# Altium schematic sheet Rectifiers.SchDoc(Rectifiers)
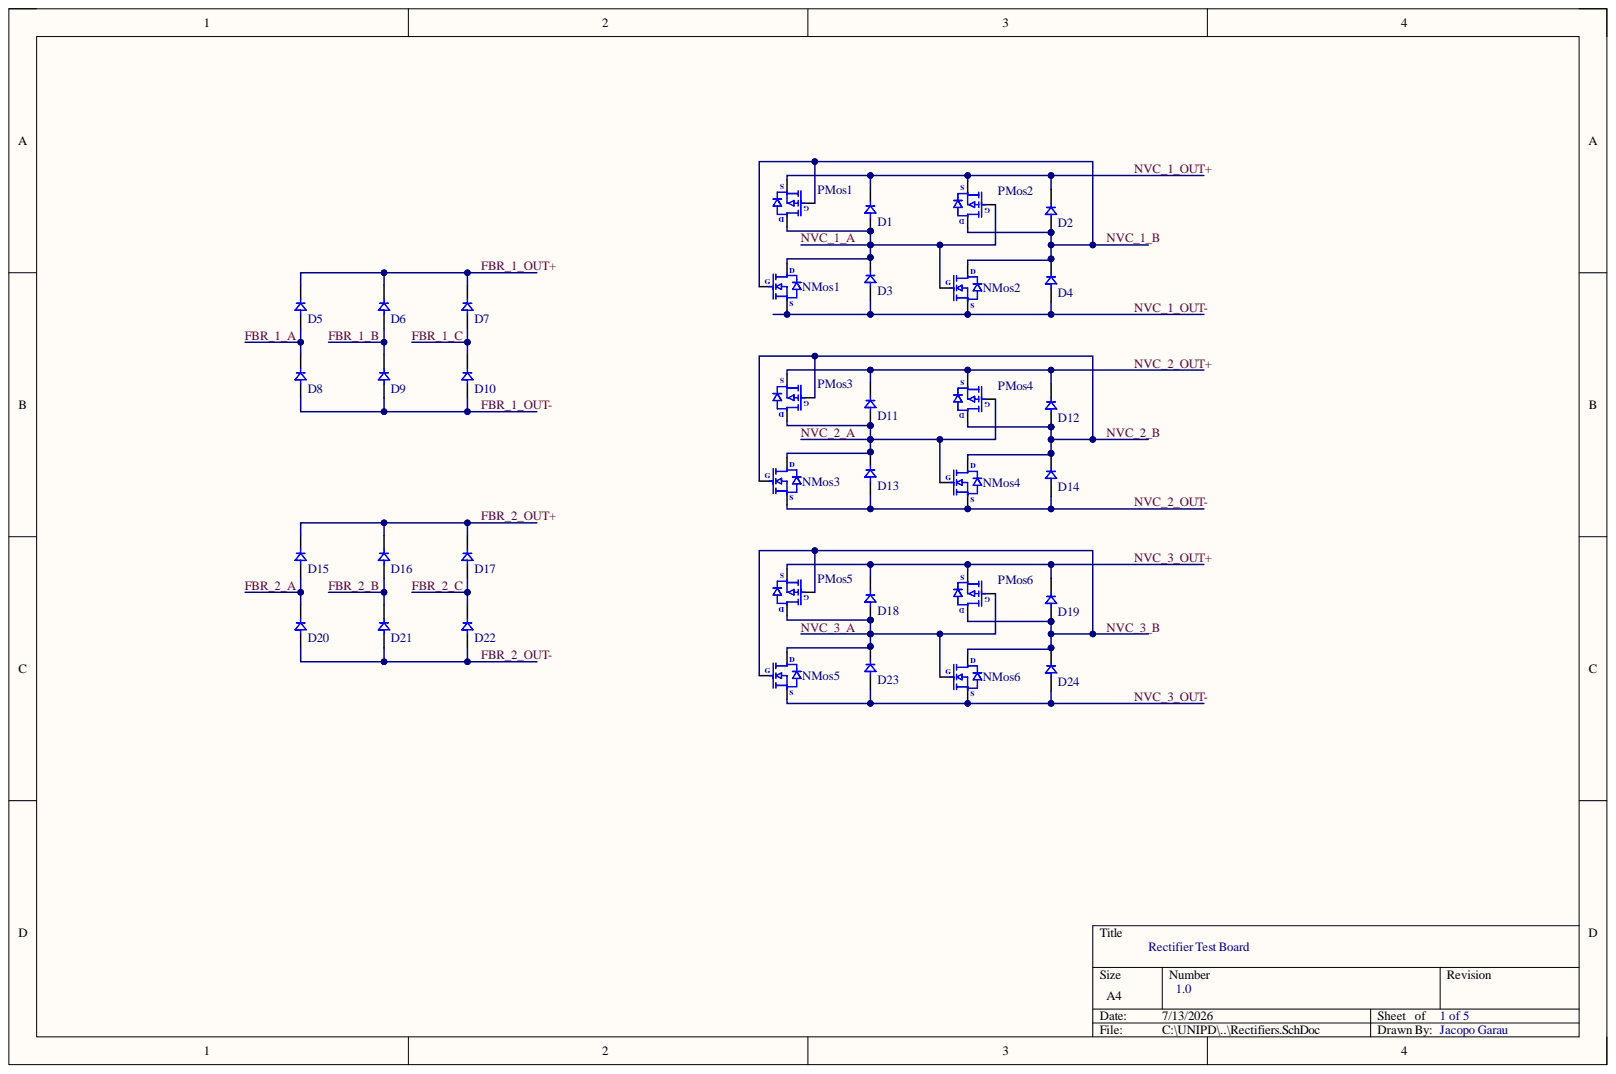
[diagram p0: Rectifiers.SchDoc]

## Parts
D1  CTS05S40,L3F  [CST2_TOS]  (value not drawn)
D2  CTS05S40,L3F  [CST2_TOS]  (value not drawn)
D3  CTS05S40,L3F  [CST2_TOS]  (value not drawn)
D4  CTS05S40,L3F  [CST2_TOS]  (value not drawn)
D5  CTS05S40,L3F  [CST2_TOS]  (value not drawn)
D6  CTS05S40,L3F  [CST2_TOS]  (value not drawn)
D7  CTS05S40,L3F  [CST2_TOS]  (value not drawn)
D8  CTS05S40,L3F  [CST2_TOS]  (value not drawn)
D9  CTS05S40,L3F  [CST2_TOS]  (value not drawn)
D10  CTS05S40,L3F  [CST2_TOS]  (value not drawn)
D11  CTS05S40,L3F  [CST2_TOS]  (value not drawn)
D12  CTS05S40,L3F  [CST2_TOS]  (value not drawn)
D13  CTS05S40,L3F  [CST2_TOS]  (value not drawn)
D14  CTS05S40,L3F  [CST2_TOS]  (value not drawn)
D15  CTS05S40,L3F  [CST2_TOS]  (value not drawn)
D16  CTS05S40,L3F  [CST2_TOS]  (value not drawn)
D17  CTS05S40,L3F  [CST2_TOS]  (value not drawn)
D18  CTS05S40,L3F  [CST2_TOS]  (value not drawn)
D19  CTS05S40,L3F  [CST2_TOS]  (value not drawn)
D20  CTS05S40,L3F  [CST2_TOS]  (value not drawn)
D21  CTS05S40,L3F  [CST2_TOS]  (value not drawn)
D22  CTS05S40,L3F  [CST2_TOS]  (value not drawn)
D23  CTS05S40,L3F  [CST2_TOS]  (value not drawn)
D24  CTS05S40,L3F  [CST2_TOS]  (value not drawn)
NMos1  BSS138PW,115  [SC-70_SOT323_NEX-L]  (value not drawn)
NMos2  BSS138PW,115  [SC-70_SOT323_NEX-L]  (value not drawn)
NMos3  BSS138PW,115  [SC-70_SOT323_NEX-L]  (value not drawn)
NMos4  BSS138PW,115  [SC-70_SOT323_NEX-L]  (value not drawn)
NMos5  BSS138PW,115  [SC-70_SOT323_NEX-L]  (value not drawn)
NMos6  BSS138PW,115  [SC-70_SOT323_NEX-L]  (value not drawn)
PMos1  PMN27XPE,115  [PMN27XPE,115]  (value not drawn)
PMos2  PMN27XPE,115  [PMN27XPE,115]  (value not drawn)
PMos3  PMN27XPE,115  [PMN27XPE,115]  (value not drawn)
PMos4  PMN27XPE,115  [PMN27XPE,115]  (value not drawn)
PMos5  PMN27XPE,115  [PMN27XPE,115]  (value not drawn)
PMos6  PMN27XPE,115  [PMN27XPE,115]  (value not drawn)

## Nets
FBR_1_A: D5.2 D8.1
FBR_1_B: D6.2 D9.1
FBR_1_C: D7.2 D10.1
FBR_1_OUT+: D5.1 D6.1 D7.1
FBR_1_OUT-: D8.2 D9.2 D10.2
FBR_2_A: D15.2 D20.1
FBR_2_B: D16.2 D21.1
FBR_2_C: D17.2 D22.1
FBR_2_OUT+: D15.1 D16.1 D17.1
FBR_2_OUT-: D20.2 D21.2 D22.2
NVC_1_A: D1.2 D3.1 NMos1.3 NMos2.1 PMos1.1 PMos2.3
NVC_1_B: D2.2 D4.1 NMos1.1 NMos2.3 PMos1.3 PMos2.1
NVC_1_OUT+: D1.1 D2.1 PMos1.4 PMos2.4
NVC_1_OUT-: D3.2 D4.2 NMos1.2 NMos2.2
NVC_2_A: D11.2 D13.1 NMos3.3 NMos4.1 PMos3.1 PMos4.3
NVC_2_B: D12.2 D14.1 NMos3.1 NMos4.3 PMos3.3 PMos4.1
NVC_2_OUT+: D11.1 D12.1 PMos3.4 PMos4.4
NVC_2_OUT-: D13.2 D14.2 NMos3.2 NMos4.2
NVC_3_A: D18.2 D23.1 NMos5.3 NMos6.1 PMos5.1 PMos6.3
NVC_3_B: D19.2 D24.1 NMos5.1 NMos6.3 PMos5.3 PMos6.1
NVC_3_OUT+: D18.1 D19.1 PMos5.4 PMos6.4
NVC_3_OUT-: D23.2 D24.2 NMos5.2 NMos6.2

## Library data of the parts
D1-D24 = CTS05S40,L3F: Rectifier Diode, Schottky, 1 Phase, 1 Element, 0.5A, 40V V(RRM), Silicon; Manufacturer: Toshiba; Average Rectified Current: 500mA; Element Configuration: Single; Forward Voltage: 600mV; Max Forward Surge Current (Ifsm): 2A; Number of Elements: 1; Peak Reverse Current: 50µA; Case/Package: SOD; Category: Rectifier Diodes; Component Kind: Standard; ComponentLink1Description: https://datasheet.octopart.com:443/CTS05S40%2CL3F-Toshiba-datasheet-181389556.pdf?src-supplier=IHS; ComponentLink1URL: https://datasheet.octopart.com:443/CTS05S40%2CL3F-Toshiba-datasheet-181389556.pdf?src-supplier=IHS; LCSC Part Number: C396063; Library Name: MySchLib.SchLib; Lifecycle Status: Production; Manufacturer Part Number: CTS05S40,L3F; Max Operating Temperature: 125°C; Max Output current: 500mA; Max Reverse Voltage (DC): 40V; Min Operating Temperature: -55°C; Mounting Technology: Surface Mount; Number of Terminals: 2; Packaging: Tape & Reel (TR); Peak Non-Repetitive Surge Current: 2A; Pins: 2; Pricing: 1=0.13, 10=0.098, 100=0.0744, 500=0.0642, 1000=0.05835, 2000=0.0504 (USD); REACH SVHC: Yes; Reverse Standoff Voltage: 40V; RoHS: Compliant; Schedule B: 8541100070, 8541100070|8541100070, 8541100070|8541100070|8541100070, 8541100070|8541100070|8541100070|8541100070; Stock: 18197; Supplier: DigiKey; Supplier Part Number: CTS05S40L3FCT-ND
NMos1-NMos6 = BSS138PW,115: Transistor MOSFET N-CH 60V 0.32A Automotive 3-Pin SC-70 T/R; Manufacturer: Nexperia; Manufacturer Lifecycle Status: RELEASED FOR SUPPLY; Continuous Drain Current (ID): 320mA; Drain to Source Resistance: 1.6Ω; Max Power Dissipation: 310mW; Number of Elements: 1; Category: MOSFETs; China RoHS: Compliant; Component Kind: Standard; ComponentLink1Description: https://datasheet.octopart.com:443/BSS138PW%2C115-Nexperia-datasheet-87581096.pdf?src-supplier=Newark; ComponentLink1URL: https://datasheet.octopart.com:443/BSS138PW%2C115-Nexperia-datasheet-87581096.pdf?src-supplier=Newark; ComponentLink2Description: https://datasheet.octopart.com:443/BSS138PW%2C115-Nexperia-datasheet-10542180.pdf?src-supplier=IHS; ComponentLink2URL: https://datasheet.octopart.com:443/BSS138PW%2C115-Nexperia-datasheet-10542180.pdf?src-supplier=IHS; ComponentLink3Description: https://datasheet.octopart.com:443/BSS138PW%2C115-Nexperia-datasheet-180923270.pdf?src-supplier=Farnell; ComponentLink3URL: https://datasheet.octopart.com:443/BSS138PW%2C115-Nexperia-datasheet-180923270.pdf?src-supplier=Farnell; ComponentLink4Description: http://sigma.octopart.com:80/reach_statement/NXP-Semiconductors-company-13.pdf?src-supplier=Compliance+Statements; ComponentLink4URL: http://sigma.octopart.com:80/reach_statement/NXP-Semiconductors-company-13.pdf?src-supplier=Compliance+Statements; ComponentLink5Description: http://sigma.octopart.com:80/rohs_statement/NXP-Semiconductors-company-12.pdf?src-supplier=Compliance+Statements; ComponentLink5URL: http://sigma.octopart.com:80/rohs_statement/NXP-Semiconductors-company-12.pdf?src-supplier=Compliance+Statements; Contact Plating: Tin; Country of Origin: Malaysia; Export Control Classification Number (ECCN) Code: EAR99; Introduction Date: 2010-11-11; LCSC Part Number: C193366; Library Name: Schlib.SchLib; Lifecycle Status: Production; Manufacturer Part Number: BSS138PW,115; Max Operating Temperature: 150°C; Min Breakdown Voltage: 60V; Number of Terminals: 3; Packaging: Tape & Reel; Pins: 3; Pricing: 1=0.2, 10=0.124, 100=0.0766, 500=0.05594, 1000=0.04921 (USD); Ratings: AEC-Q101; REACH SVHC: Yes; RoHS: Compliant; Schedule B: 8541210080, 8541210080|8541210080, 8541210080|8541210080|8541210080, 8541210080|8541210080|8541210080|8541210080; Stock: 18063; Supplier: DigiKey; Supplier Part Number: 1727-1143-1-ND
PMos1-PMos6 = PMN27XPE,115: P-Channel 20 V 4.4A (Ta) 530mW (Ta), 8.33W (Tc) Surface Mount 6-TSOP; Component Kind: Standard; ComponentLink1Description: https://datasheet.octopart.com:443/BSS138PW%2C115-Nexperia-datasheet-87581096.pdf?src-supplier=Newark; ComponentLink1URL: https://datasheet.octopart.com:443/BSS138PW%2C115-Nexperia-datasheet-87581096.pdf?src-supplier=Newark; ComponentLink2Description: https://datasheet.octopart.com:443/BSS138PW%2C115-Nexperia-datasheet-10542180.pdf?src-supplier=IHS; ComponentLink2URL: https://datasheet.octopart.com:443/BSS138PW%2C115-Nexperia-datasheet-10542180.pdf?src-supplier=IHS; ComponentLink3Description: https://datasheet.octopart.com:443/BSS138PW%2C115-Nexperia-datasheet-180923270.pdf?src-supplier=Farnell; ComponentLink3URL: https://datasheet.octopart.com:443/BSS138PW%2C115-Nexperia-datasheet-180923270.pdf?src-supplier=Farnell; ComponentLink4Description: http://sigma.octopart.com:80/reach_statement/NXP-Semiconductors-company-13.pdf?src-supplier=Compliance+Statements; ComponentLink4URL: http://sigma.octopart.com:80/reach_statement/NXP-Semiconductors-company-13.pdf?src-supplier=Compliance+Statements; ComponentLink5Description: http://sigma.octopart.com:80/rohs_statement/NXP-Semiconductors-company-12.pdf?src-supplier=Compliance+Statements; ComponentLink5URL: http://sigma.octopart.com:80/rohs_statement/NXP-Semiconductors-company-12.pdf?src-supplier=Compliance+Statements; Library Name: Schlib.SchLib
